# Altium schematic sheet IMU.SchDoc
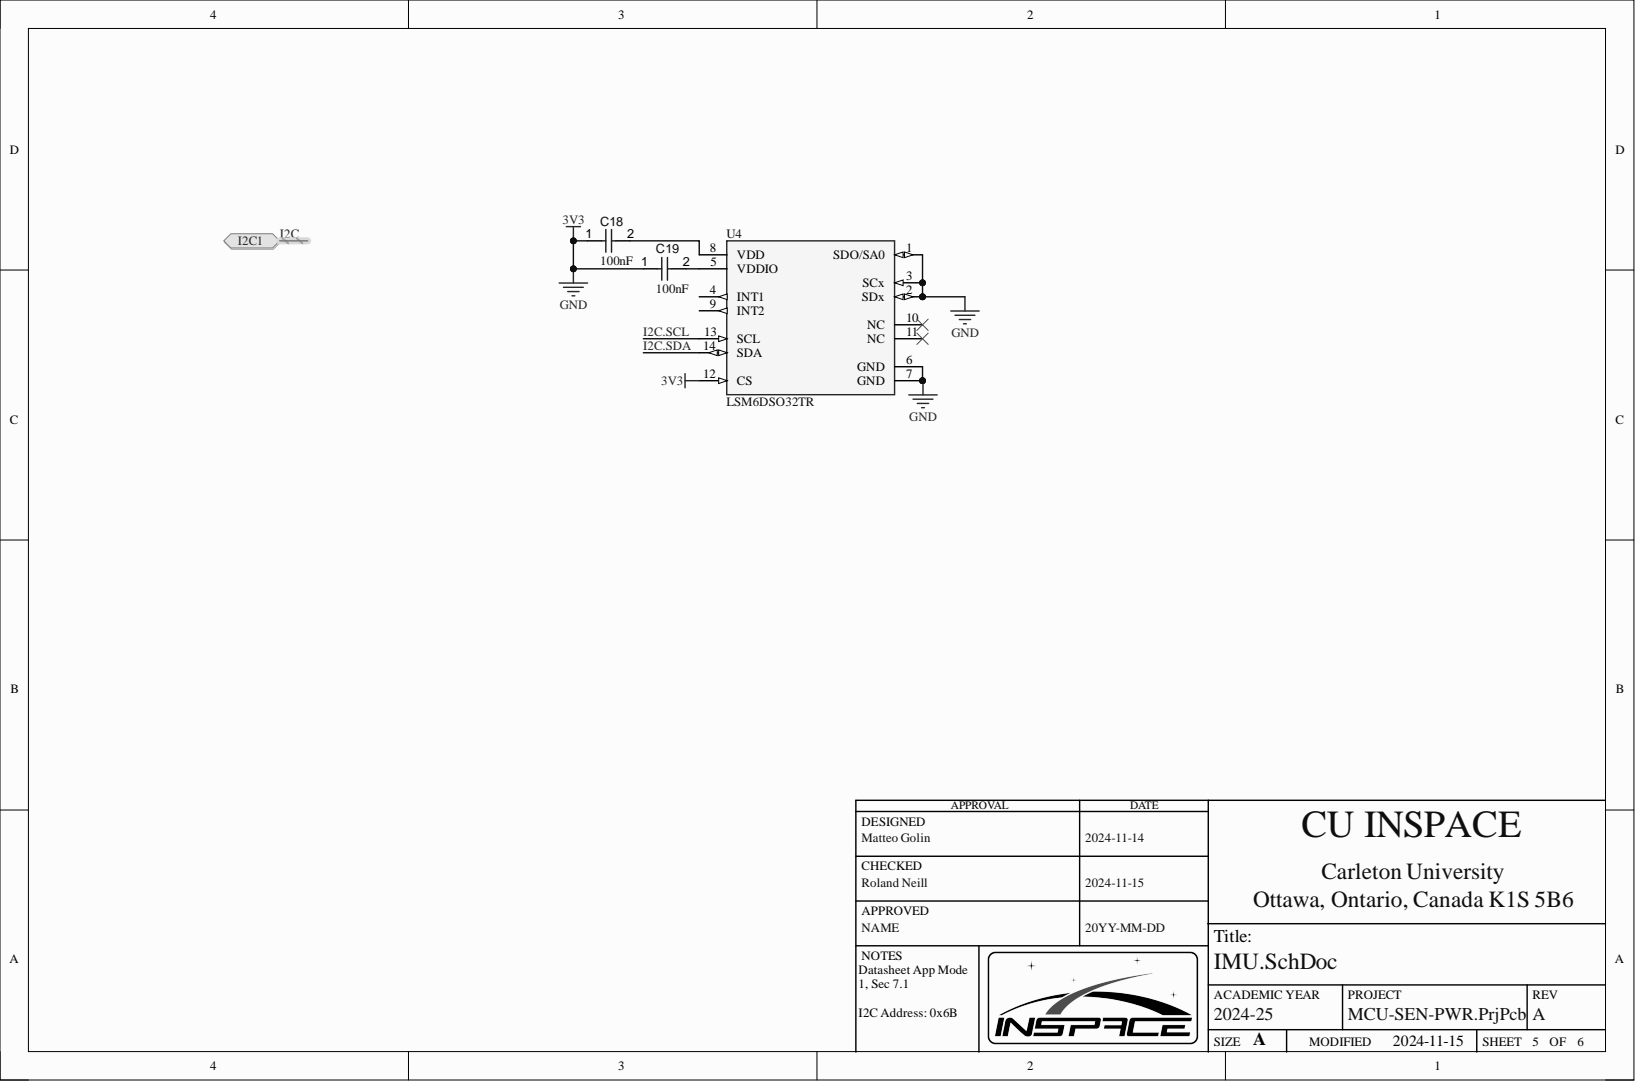
[diagram p0: IMU.SchDoc]

## Parts
C18  value 100nF  [CAPC1005X56X25LL10T15]  (hidden comment: Capacitor 0.1 uF +/- 5% 16 V 0402)
C19  value 100nF  [CAPC1005X56X25LL10T15]  (hidden comment: Capacitor 0.1 uF +/- 5% 16 V 0402)
U4  LSM6DSO32TR  [FP-LGA-14L-DM00249496-MFG]

## Ports
I2C1, I2C1.SCL, I2C1.SDA

## Nets
3V3: C18.1 C19.1 U4.1(SDO/SA0) U4.2(SDx) U4.3(SCx) U4.6(GND) U4.7(GND) U4.12(CS)
I2C.SCL: U4.13(SCL) ; port I2C1
I2C.SDA: U4.14(SDA) ; port I2C1
NetC18_2: C18.2 U4.8(VDD)
NetC19_2: C19.2 U4.5(VDDIO)

Not connected: U4 4(INT1) 9(INT2) 10(NC) 11(NC)

## Library data of the parts
C18 C19 = CMP-001-00001-6: Chip Capacitor, 0.1 uF, +/- 5%, 16 V, 0402 (1005 Metric); Capacitor Type: Ceramic; Voltage Rating: 16V; Case/Package: 0402; Component Kind: Standard; Max Operating Temperature: 125°C; Min Operating Temperature: -55°C; Mounting Technology: SM; Pins: 2; RoHS Compliant: True; Tolerance: 5%; Library Name: Americas- CU InSpace-  Carleton University
U4 = CMP-010-00032-1: Analog Circuit; Component Kind: Standard; RoHS Compliant: Yes; Library Name: Americas- CU InSpace-  Carleton University
